# Altium schematic sheet Schematic Prints("All Documents",Logical)
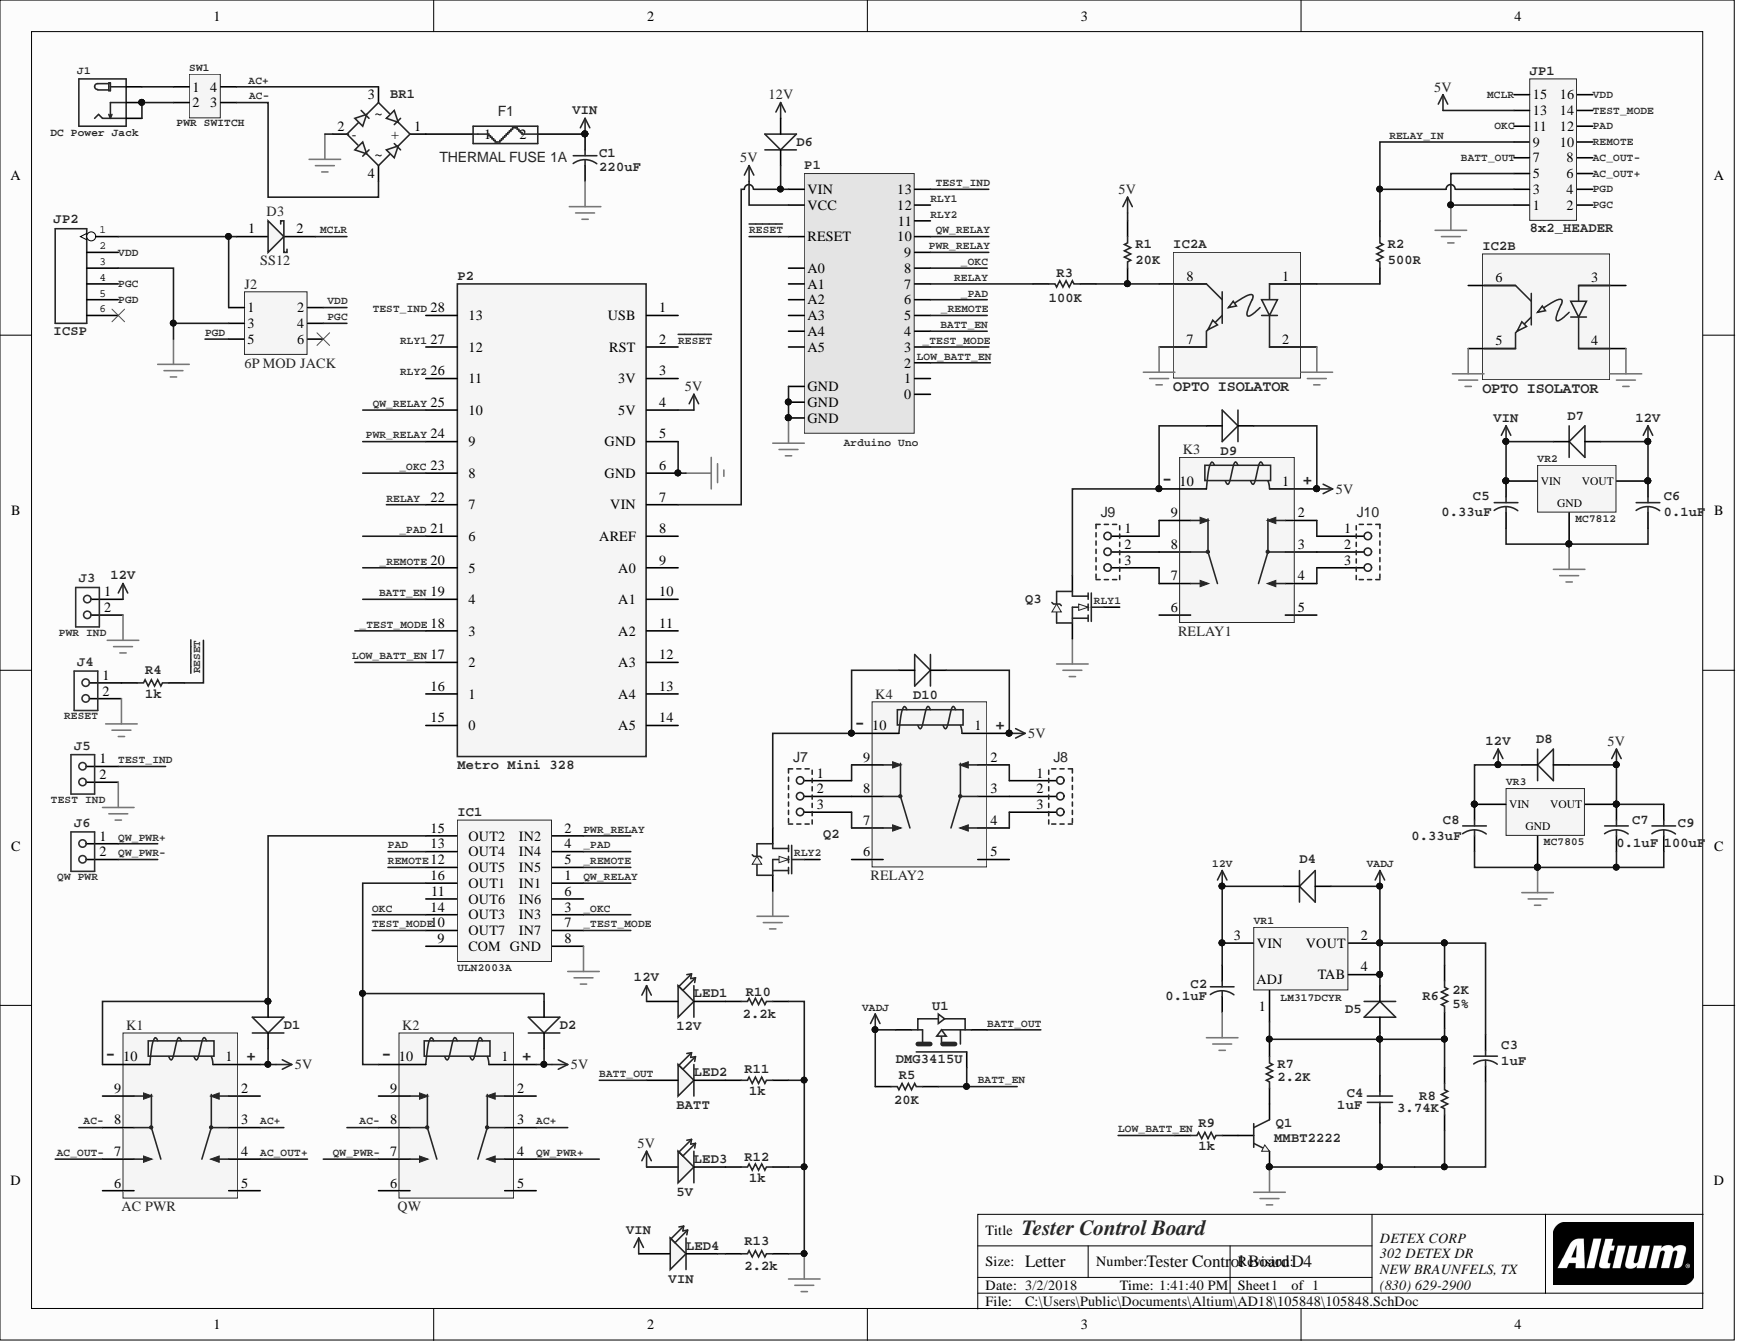
[diagram p0: Schematic Prints("All Documents",Logical)]

## Parts
BR1  BRIDGE - SMT  [BRIDGE_1A_SMT]  (value not drawn)
C1  value 220uF  [CAPR5-4X5]  (hidden comment: Capacitor - Thru-Hole)
C2  value 0.1uF  [CAPC1206(3216)110_M]  (hidden comment: 1206 Capacitor)
C3  value 1uF  [CAPC1206(3216)110_M]  (hidden comment: 1206 Capacitor)
C4  value 1uF  [CAPC1206(3216)110_M]  (hidden comment: 1206 Capacitor)
C5  value 0.33uF  [RESC1206(3216)_M]  (hidden comment: 1206 Capacitor)
C6  value 0.1uF  [RESC1206(3216)_M]  (hidden comment: 1206 Capacitor)
C7  value 0.1uF  [RESC1206(3216)_M]  (hidden comment: 1206 Capacitor)
C8  value 0.33uF  [RESC1206(3216)_M]  (hidden comment: 1206 Capacitor)
C9  value 100uF  [CAPR5-4X5]  (hidden comment: Capacitor - Thru-Hole)
D1  Diode SMT  [SOT-23_M]  (value not drawn)
D2  Diode SMT  [SOT-23_M]  (value not drawn)
D3  SS12  [SMB_2]
D4  1N4002  [DO-214AC]  (value not drawn)
D5  1N4002  [DO-214AC]  (value not drawn)
D6  1N4002  [DO-214AC]  (value not drawn)
D7  1N4002  [DO-214AC]  (value not drawn)
D8  1N4002  [DO-214AC]  (value not drawn)
D9  1N4002  [SOT-23_M]  (value not drawn)
D10  1N4002  [SOT-23_M]  (value not drawn)
F1  THERMAL FUSE 1A  [FUSE_200]
IC1  ULN2003A  [SO-16_M]
IC2  OPTO ISOLATOR  [SO8_N]  (parts A,B)
J1  DC Power Jack  [KLD-0202_2]
J2  6P MOD JACK  [TECO-5520470-3_V]
J3  PWR IND  [JMP2]
J4  RESET  [JMP2]
J5  TEST IND  [JMP2]
J6  QW PWR  [JMP2]
J7  JMP_3PIN  [JMP3]  (value not drawn)
J8  JMP_3PIN  [JMP3]  (value not drawn)
J9  JMP_3PIN  [JMP3]  (value not drawn)
J10  JMP_3PIN  [JMP3]  (value not drawn)
JP1  8x2_HEADER  [HDR2X8]
JP2  ICSP  [HDR1X6]
K1  AC PWR  [AZ_940]
K2  QW  [AZ_940]
K3  RELAY1  [AZ_940]
K4  RELAY2  [AZ_940]
LED1  12V  [LED-0805]
LED2  BATT  [LED-0805]
LED3  5V  [LED-0805]
LED4  VIN  [LED-0805]
P1  Arduino Uno  [ArduinoUno]
P2  Metro Mini 328  [Adafruit Metro Mini]
Q1  MMBT2222  [SOT-23_M]
Q2  2N7002  [SOT-23_M]  (value not drawn)
Q3  2N7002  [SOT-23_M]  (value not drawn)
R1  value 20K  [RESC1206(3216)_M]  (hidden comment: Resistor)
R2  value 500R  [RESC1206(3216)_M]  (hidden comment: Resistor)
R3  value 100K  [RESC1206(3216)_M]  (hidden comment: Resistor)
R4  value 1k  [RESC1206(3216)_M]  (hidden comment: Resistor)
R5  value 20K  [RESC1206(3216)_M]  (hidden comment: Resistor)
R6  value 2K  Tolerance: 5%  [RESC1206(3216)_M]  (hidden comment: Resistor)
R7  value 2.2K  [RESC1206(3216)_M]  (hidden comment: Resistor)
R8  value 3.74K  [RESC1206(3216)_M]  (hidden comment: Resistor)
R9  value 1k  [RESC1206(3216)_M]  (hidden comment: Resistor)
R10  value 2.2k  [RESC1206(3216)_M]  (hidden comment: Resistor)
R11  value 1k  [RESC1206(3216)_M]  (hidden comment: Resistor)
R12  value 1k  [RESC1206(3216)_M]  (hidden comment: Resistor)
R13  value 2.2k  [RESC1206(3216)_M]  (hidden comment: Resistor)
SW1  PWR SWITCH  [DPST-4]  (no BOM)
U1  value DMG3415U  MPN: DMG3415U  [SOT-23_M]  (hidden comment: MOSFET P-CHANNEL)
VR1  LM317DCYR  [SOT223_M]
VR2  MC7812  [D2PAK]
VR3  MC7805  [D2PAK]

## Nets
5V: C7.1 C9.1 D1.- D2.- D8.+ D9.- D10.- JP1.13 K1.1 K2.1 K3.1 K4.1 LED3.+ P1.VCC P2.4(5V) R1.1 VR3.3
12V: C2.1 C6.1 C8.1 D4.- D6.+ D7.+ D8.- J3.1 LED1.+ VR1.3(VIN) VR2.3 VR3.1
GND: BR1.2 C1.2 C2.2 C3.2 C4.1 C5.2 C6.2 C7.2 C8.2 C9.2 IC1.8(GND) IC2.2 IC2.4 IC2.5 IC2.7 J2.3 J3.2 J4.2 J5.2 JP1.1 JP1.5 JP2.3 P1.GND P1.GND1(GND) P1.GND2(GND) P2.5(GND) P2.6(GND) Q1.2 Q2.2 Q3.2 R8.2 R10.2 R11.2 R12.2 R13.2 VR2.2 VR3.2
VADJ: C3.1 D4.+ D5.- R5.2 R6.1 U1.2 VR1.2(VOUT) VR1.4(TAB)
VIN: C1.1 C5.1 D7.- F1.2 LED4.+ VR2.1
_OKC: IC1.3(IN3) P1.8 P2.23
_PAD: IC1.4(IN4) P1.6 P2.21
_REMOTE: IC1.5(IN5) P1.5 P2.20
_TEST_MODE: IC1.7(IN7) P1.3 P2.18
AC+: BR1.3(~) K1.3 K2.3 SW1.4
AC-: BR1.4(~) K1.8 K2.8 SW1.3
AC_OUT+: JP1.6 K1.4
AC_OUT-: JP1.8 K1.7
BATT_EN: P1.4 P2.19 R5.1 U1.1
BATT_OUT: JP1.7 LED2.+ U1.3
LOW_BATT_EN: P1.2 P2.17 R9.1
MCLR: D3.2 JP1.15
OKC: IC1.14(OUT3) JP1.11
PAD: IC1.13(OUT4) JP1.12
PGC: J2.4 JP1.2 JP2.4
PGD: J2.5 JP1.4 JP2.5
PWR_RELAY: IC1.2(IN2) P1.9 P2.24
QW_PWR+: J6.1 K2.4
QW_PWR-: J6.2 K2.7
QW_RELAY: IC1.1(IN1) P1.10 P2.25
~{RESET}: P1.RESET P2.2(RST) R4.2
RELAY: P1.7 P2.22 R3.2
RELAY_IN: JP1.3 JP1.9 R2.1
REMOTE: IC1.12(OUT5) JP1.10
RLY1: P1.12 P2.27 Q3.1
RLY2: P1.11 P2.26 Q2.1
TEST_IND: J5.1 P1.13 P2.28
TEST_MODE: IC1.10(OUT7) JP1.14
VDD: J2.2 JP1.16 JP2.2
NetBR1_1: BR1.1(+) F1.1
NetC4_2: C4.2 D5.+ R6.2 R7.1 R8.1 VR1.1
NetD1_+: D1.+ IC1.15(OUT2) K1.10
NetD2_+: D2.+ IC1.16(OUT1) K2.10
NetD3_1: D3.1 J2.1 JP2.1
NetD6_-: D6.- P1.VIN P2.7(VIN)
NetD9_+: D9.+ K3.10 Q3.3
NetD10_+: D10.+ K4.10 Q2.3
NetIC1_6: IC1.6(IN6)
NetIC1_9: IC1.9(COM)
NetIC1_11: IC1.11(OUT6)
NetIC2_1: IC2.1 R2.2
NetIC2_3: IC2.3
NetIC2_6: IC2.6
NetIC2_8: IC2.8 R1.2 R3.1
NetJ1_+: J1.+ SW1.1
NetJ1_-1: J1.-1 J1.-2 SW1.2
NetJ2_6: J2.6
NetJ4_1: J4.1 R4.1
NetJ7_1: J7.1 K4.9
NetJ7_2: J7.2 K4.8
NetJ7_3: J7.3 K4.7
NetJ8_1: J8.1 K4.2
NetJ8_2: J8.2 K4.3
NetJ8_3: J8.3 K4.4
NetJ9_1: J9.1 K3.9
NetJ9_2: J9.2 K3.8
NetJ9_3: J9.3 K3.7
NetJ10_1: J10.1 K3.2
NetJ10_2: J10.2 K3.3
NetJ10_3: J10.3 K3.4
NetJP2_6: JP2.6
NetK1_2: K1.2
NetK1_5: K1.5
NetK1_6: K1.6
NetK1_9: K1.9
NetK2_2: K2.2
NetK2_5: K2.5
NetK2_6: K2.6
NetK2_9: K2.9
NetK3_5: K3.5
NetK3_6: K3.6
NetK4_5: K4.5
NetK4_6: K4.6
NetLED1_-: LED1.- R10.1
NetLED2_-: LED2.- R11.1
NetLED3_-: LED3.- R12.1
NetLED4_-: LED4.- R13.1
NetP1_0: P1.0
NetP1_1: P1.1
NetP1_A0: P1.A0
NetP1_A1: P1.A1
NetP1_A2: P1.A2
NetP1_A3: P1.A3
NetP1_A4: P1.A4
NetP1_A5: P1.A5
NetP2_1: P2.1(USB)
NetP2_3: P2.3(3V)
NetP2_8: P2.8(AREF)
NetP2_9: P2.9(A0)
NetP2_10: P2.10(A1)
NetP2_11: P2.11(A2)
NetP2_12: P2.12(A3)
NetP2_13: P2.13(A4)
NetP2_14: P2.14(A5)
NetP2_15: P2.15
NetP2_16: P2.16
NetQ1_1: Q1.1 R9.2
NetQ1_3: Q1.3 R7.2

## Library data of the parts
BR1 = BRIDGE_1A_SMT: 1A SMT Bridge Rectifier; Component Kind: Standard; Library Name: AltiumIntegratedLibrary.IntLib; Simulation: SUBCKT; Value: 1A SMT Bridge Rectifier
C1 C9 = CAP200: Capacitor TO - 200 SPACING; Component Kind: Standard; Library Name: Train2.SchLib
C2 C3 C5-C8 = CAP200: 1206 Ceramic Capacitor; Component Kind: Standard; PackageDescription: 1206; PackageReference: 1206; Library Name: Train2.SchLib
C4 = Cap: 1206 Ceramic Capacitor; Component Kind: Standard; Library Name: Miscellaneous Devices.SchLib; PackageDescription: 1206; PackageReference: 1206; Simulation: CAP
D1 D2 = SMD-DIODE-SCHOTTKY-20V-1A(SOD-123): 1N5400; MPN: B5819W; Value: Diode 1N5400; Component Kind: Standard; Library Name: Seeed-Diode-2016.IntLib
D3 = D Schottky: Schottky Diode, SMD; Component Kind: Standard; ComponentLink1Description: Datasheet; ComponentLink1URL: https://www.vishay.com/docs/88746/ss12.pdf; Library Name: Miscellaneous Devices.SchLib; MPN: SS12; PackageDescription: DO-214-AA/SMB; 2 C-Bend Leads; Body 5.3 x 3.6 mm, inc. leads (LxW); PackageReference: SMA; Simulation: SKYDIODE; Value: SS12
D4-D9 = SMD-DIODE-SCHOTTKY-20V-1A(SOD-123): Diode, SMD; MPN: B5819W; Component Kind: Standard; Library Name: AltiumIntegratedLibrary.IntLib; Value: 1N4002
D10 = SMD-DIODE-SCHOTTKY-20V-1A(SOD-123): Diode, SMD; MPN: B5819W; Value: Diode 1N5400; Component Kind: Standard; Library Name: AltiumIntegratedLibrary.IntLib
F1 = FUSE_200: Value: 1A fuse; Component Kind: Standard; Description: FUSE_200; Library Name: Train2.SchLib
IC1 = ULN2003: Component Kind: Standard; ComponentLink1Description: Datasheet; ComponentLink1URL: https://www.diodes.com/assets/Datasheets/ULN200xA.pdf; Description: ULN2003; MPN: ULN2003A; Value: ULN2003A; Library Name: Train2.SchLib
IC2 = OPTO_DUAL_2: DUAL OPTO ISOLATOR; MPN: ILD207T; Value: ILD207T; Component Kind: Standard; ComponentLink1Description: Datasheet; ComponentLink1URL: http://www.vishay.com/docs/83647/ild205t.pdf; Library Name: AltiumIntegratedLibrary.IntLib
J1 = CONN-POWER-JACK-2.1MM(DC-005): DC POWER PLUG; MPN: DC-005; Value: KLD-0202_2; Component Kind: Standard; Library Name: Seeed-Connector -2016.IntLib
J2 = Modular Jack 6P: 6 Position Modular Jack Connector; Manufacturer: Tetco; Value: TECO-5520470-3_V; Component Kind: Standard; Library Name: 105848_revD3.SchLib; MPN: TECO-5520470-3_V
J3-J6 = JUMPER_2: Component Kind: Standard; Description: JUMPER_2; Library Name: 105848.SCHLIB; Value: JUMPER_2
JP1 = 15C_2ROW_HEADER: Ribbon Cable Connection; Component Kind: Standard; Library Name: 105848.SCHLIB; Value: 8x2_HEADER
JP2 = DIP-BLACK-MALE-HEADER(6P-2.54): MPN: P125-1106A1BS116A1; Component Kind: Standard; Description: DIP-BLACK-MALE-HEADER(6P-2.54); Value: ICSP; Library Name: Seeed-Connector -2016.IntLib
K1-K4 = Relay_1: AZ850-5; Manufacturer: Zetler; Part Number: 101671; Component Kind: Standard; ComponentLink1Description: Datasheet; ComponentLink1URL: http://www.azettler.com/pdfs/az850.pdf; Library Name: 105848.SCHLIB; MPN: AZ850-5; Value: AZ850-5
LED1 = SMD-LED-CLEAR-RED(0805): 12V Power Indicator; MPN: 17-215SURC/S530-A2/TR8; Component Kind: Standard; Library Name: 105848.SCHLIB; PackageDescription: 0805; PackageReference: 0805; Value: LED-0805
LED2 = SMD-LED-CLEAR-RED(0805): Battery Power Indicator; MPN: 17-215SURC/S530-A2/TR8; Component Kind: Standard; Library Name: 105848.SCHLIB; PackageDescription: 0805; PackageReference: 0805; Value: LED-0805
LED3 = SMD-LED-CLEAR-RED(0805): 5V Power Indicator; MPN: 17-215SURC/S530-A2/TR8; Component Kind: Standard; Library Name: 105848.SCHLIB; PackageDescription: 0805; PackageReference: 0805; Value: LED-0805
LED4 = SMD-LED-CLEAR-RED(0805): Power Input Indicator; MPN: 17-215SURC/S530-A2/TR8; Component Kind: Standard; Library Name: 105848.SCHLIB; PackageDescription: 0805; PackageReference: 0805; Value: LED-0805
P1 = Arduino Uno: Manufacturer: Arduino.cc; Component Kind: Standard; Description: Arduino Uno; Library Name: 105848.SCHLIB; Value: Arduino Uno
P2 = Metro Mini: Adafruit METRO Mini 328 rev A; Manufacturer: Adafruit Industries; MPN: 2590; Component Kind: Standard; ComponentLink1Description: Datasheet; ComponentLink1URL: https://github.com/adafruit/Adafruit-METRO-328-PCB/raw/master/Metro%20Mini%20Rev%20A.pdf; Value: Metro Mini 328; Library Name: Train2.SchLib
Q1 = 2N3904_SMT: NPN Bipolar Transistor; Component Kind: Standard; ComponentLink1Description: Datasheet; ComponentLink1URL: http://datasheet.octopart.com/MMBT2222-Fairchild-datasheet-39713.pdf; MPN: MMBT2222; PackageDescription: SOT-23; PackageReference: SOT-23; Simulation: SUBCKT; Value: MMBT2222; Library Name: Train2.SchLib
Q2 Q3 = NMOS-2: N-Channel Enhancement Mode FET; Component Kind: Standard; ComponentLink1Description: Datasheet; ComponentLink1URL: http://www.onsemi.com/pub/Collateral/NDS7002A-D.PDF; Library Name: 105848.SCHLIB; MPN: 2N7002; PackageDescription: SOT-23; PackageReference: SOT-23; Simulation: IRF1010; Value: 2N7002
R1-R5 R7-R13 = CMP-2003-01077-1: RESISTOR, SMD, 1206; Tolerance: 5%; Component Kind: Standard; PackageDescription: 1206; PackageReference: 1206
R6 = CMP-2003-01077-1: RESISTOR, SMD, 1206; Component Kind: Standard; PackageDescription: 1206; PackageReference: 1206
SW1 = Header 2x2: Wire-Board Connection; Library Name: 105848_revD3.SchLib; PackageDescription: 1206; PackageReference: 1206; Value: Header 2x2
U1 = P-CHANNEL MOSFET: Manufacturer: Diodes, Inc.; Component Kind: Standard; ComponentLink1Description: Datasheet; ComponentLink1URL: https://www.diodes.com/assets/Datasheets/ds31735.pdf; Description: MOSFET P-CHANNEL; Library Name: 105848.SCHLIB; PackageDescription: SOT-23; PackageReference: SOT-23
VR1 = LM317: Adjustable SMT Voltage Regulator; Manufacturer: TI; Value: LM317; Component Kind: Standard; ComponentLink1Description: Datasheet; ComponentLink1URL: http://www.ti.com/lit/ds/symlink/lm317.pdf; Library Name: 105848.SCHLIB; MPN: LM317DCYR; PackageDescription: SOT-23-4; PackageReference: SOT-23-4; Simulation: SUBCKT
VR2 = MC7812: SMT 12V Fixed Output Voltage Regulator; Manufacturer: ON Semi; MPN: MC7812CD2TG; Value: MC7812CD2TG; Component Kind: Standard; ComponentLink1Description: Datasheet; ComponentLink1URL: https://www.onsemi.com/pub/Collateral/MC7800-D.PDF; Library Name: 105848.SCHLIB; PackageDescription: DPAK; PackageReference: DPAK; Simulation: SUBCKT
VR3 = MC7812: SMT 5V Linear Voltage Regulator; Manufacturer: ON Semi; MPN: MC7805BDTRKG; Value: MC7805BDTRKG; Component Kind: Standard; ComponentLink1Description: Datasheet; ComponentLink1URL: https://www.onsemi.com/pub/Collateral/MC7800-D.PDF; Library Name: 105848.SCHLIB; PackageDescription: DPAK-3; PackageReference: DPAK-3; Simulation: SUBCKT
J7-J10 = JMP_3PIN: Component Kind: Standard; Description: JMP_3PIN; Library Name: AltiumIntegratedLibrary.IntLib; Value: JMP_3PIN
